# Altium schematic sheet SH2 - 5V VICOR DCDC.SchDoc
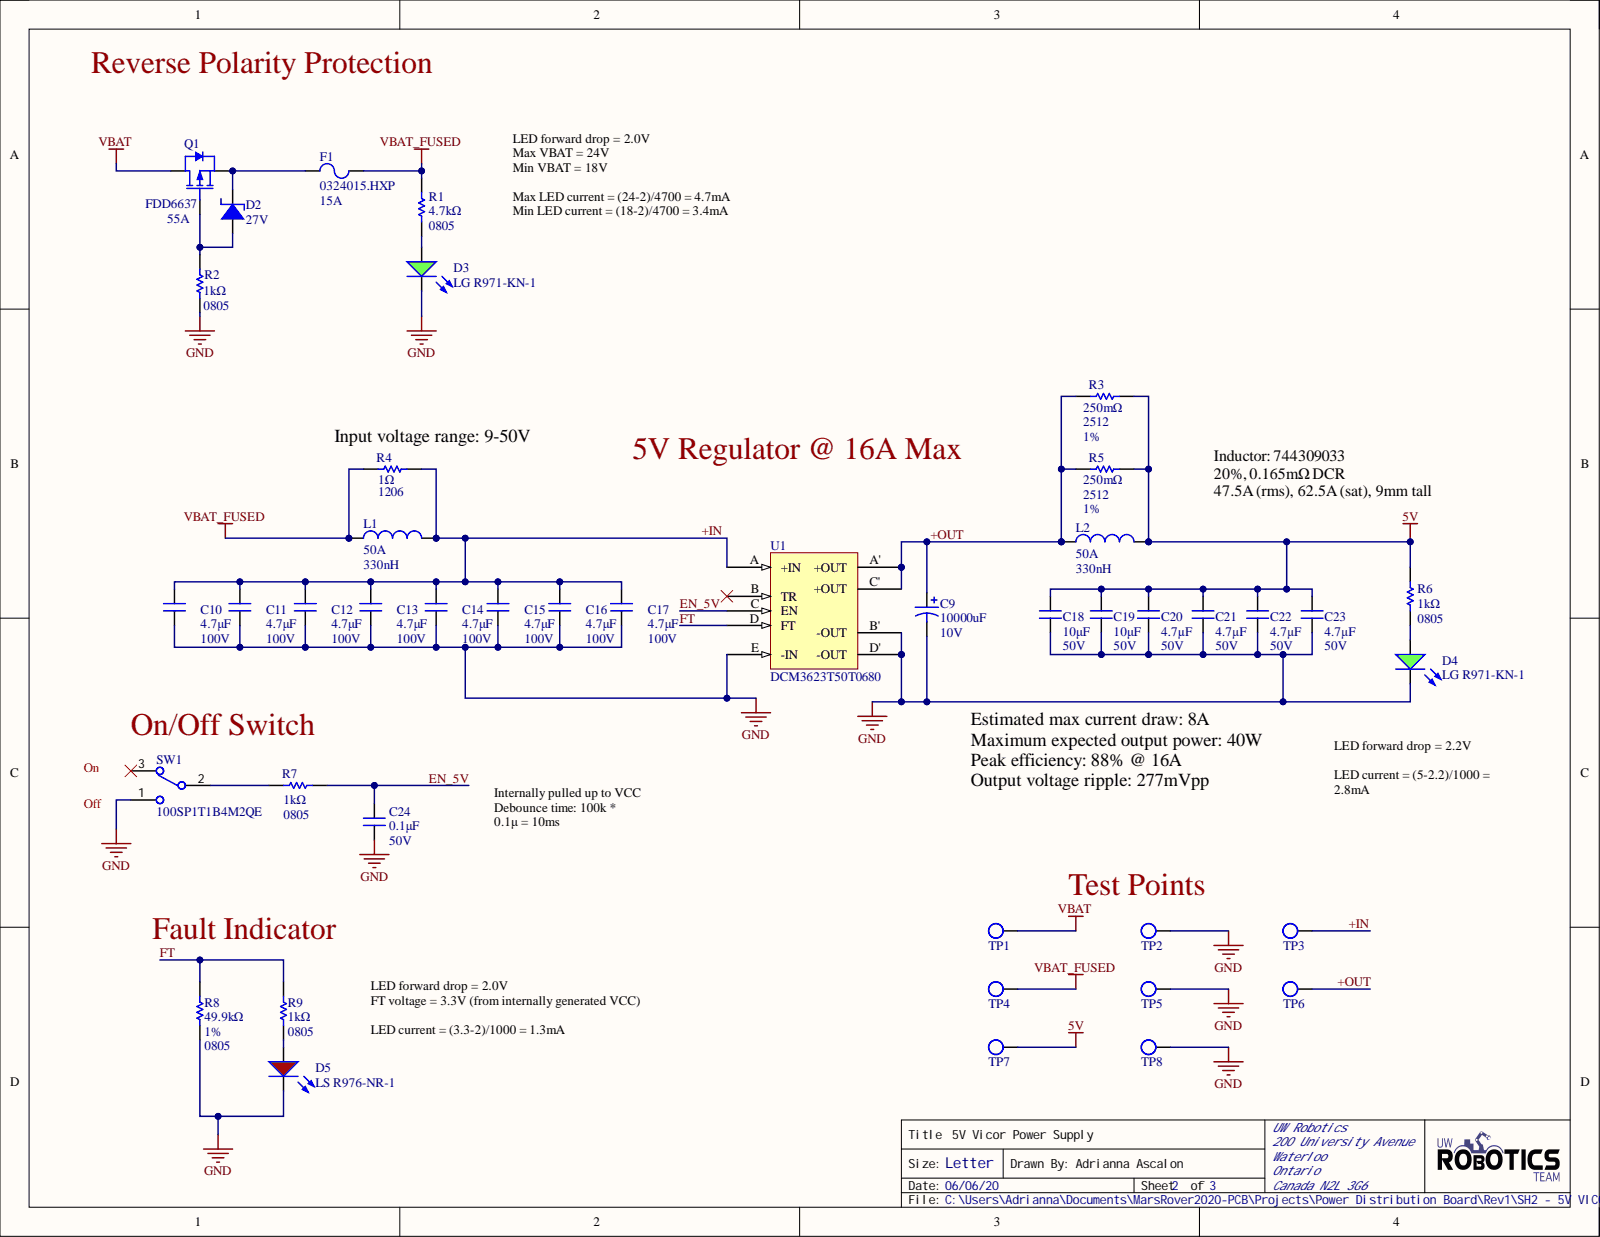
[diagram p0: SH2 - 5V VICOR DCDC.SchDoc]

## Parts
C9  Capacitance: 10000uF  Voltage Rating: 10V  [CAP_UBY_18X25_NC]  (hidden comment: URS1A103MHD1TN)
C10  4.7μF  Voltage: 100V  [CAPC5750X7R2A]
C11  4.7μF  Voltage: 100V  [CAPC5750X7R2A]
C12  4.7μF  Voltage: 100V  [CAPC5750X7R2A]
C13  4.7μF  Voltage: 100V  [CAPC5750X7R2A]
C14  4.7μF  Voltage: 100V  [CAPC5750X7R2A]
C15  4.7μF  Voltage: 100V  [CAPC5750X7R2A]
C16  4.7μF  Voltage: 100V  [CAPC5750X7R2A]
C17  4.7μF  Voltage: 100V  [CAPC5750X7R2A]
C18  value 10μF  Voltage Rating: 50V  [CAPC1206(3216)]  (hidden comment: 10uF)
C19  value 10μF  Voltage Rating: 50V  [CAPC1206(3216)]  (hidden comment: 10uF)
C20  4.7µF  Voltage: 50V  [CAPC1206(3216)]
C21  4.7µF  Voltage: 50V  [CAPC1206(3216)]
C22  4.7µF  Voltage: 50V  [CAPC1206(3216)]
C23  4.7µF  Voltage: 50V  [CAPC1206(3216)]
C24  0.1μF  Voltage Rating: 50V  [CAPC2012X140N]
D2  Working Voltage: 27V  [SOT23-3]  (hidden comment: DIO_ZENER_27V)
D3  LG R971-KN-1  [LED_0805_LINE]
D4  LG R971-KN-1  [LED_0805_LINE]
D5  LS R976-NR-1  [LED_0805_LINE]
F1  0324015.HXP  Current Rating: 15A  [FUSE]
L1  744309033  Inductance: 330nH  Max DC Current: 50A  [WE-HCM 1390]
L2  744309033  Inductance: 330nH  Max DC Current: 50A  [WE-HCM 1390]
Q1  FDD6637  Continuous Drain Current (ID): 55A  [D-PAK]
R1  value 4.7kΩ  Case Code (Imperial): 0805  [RESC2012X60N]  (hidden comment: RES_4.7kΩ_0805)
R2  1kΩ  Case Code (Imperial): 0805  [RESC2012X60N]
R3  Case Code (Imperial): 2512  Resistance: 250mΩ  [RESC6432X89X76NL25T25]  (hidden comment: WSL2512R2500FEA)
R4  Case Code (Imperial): 1206  Resistance: 1Ω  [RESC1206(3216)_N]  (hidden comment: RES_1Ω_1206)
R5  Case Code (Imperial): 2512  Resistance: 250mΩ  Resistance Tolerance: 1%  [RESC6432X89X76NL25T25]  (hidden comment: WSL2512R2500FEA)
R6  1kΩ  Case Code (Imperial): 0805  [RESC2012X60N]
R7  1kΩ  Case Code (Imperial): 0805  [RESC2012X60N]
R8  49.9kΩ  Case Code (Imperial): 0805  Tolerance: 1%  [RESC2012X60N]
R9  1kΩ  Case Code (Imperial): 0805  [RESC2012X60N]
SW1  100SP1T1B4M2QE  [100SP1T1B4M2QE]
TP1  TESTPOINT_LOOP  [TESTPOINT_LOOP]  (value not drawn)
TP2  TESTPOINT_LOOP  [TESTPOINT_LOOP]  (value not drawn)
TP3  TESTPOINT_LOOP  [TESTPOINT_LOOP]  (value not drawn)
TP4  TESTPOINT_LOOP  [TESTPOINT_LOOP]  (value not drawn)
TP5  TESTPOINT_LOOP  [TESTPOINT_LOOP]  (value not drawn)
TP6  TESTPOINT_LOOP  [TESTPOINT_LOOP]  (value not drawn)
TP7  TESTPOINT_LOOP  [TESTPOINT_LOOP]  (value not drawn)
TP8  TESTPOINT_LOOP  [TESTPOINT_LOOP]  (value not drawn)
U1  DCM3623T50T0680  [DCM3623T50T0680]

## Nets
5V: C18.2 C19.2 C20.2 C21.2 C22.2 C23.2 L2.2 R3.2 R5.2 R6.2 TP7.1
GND: C9.2 C10.1 C11.1 C12.1 C13.1 C14.1 C15.1 C16.1 C17.1 C18.1 C19.1 C20.1 C21.1 C22.1 C23.1 C24.1 D3.2 D4.2 D5.2 R2.1 R8.2 SW1.1 TP2.1 TP5.1 TP8.1 U1.B'(-OUT) U1.D'(-OUT) U1.E(-IN)
VBAT: Q1.2 TP1.1
VBAT_FUSED: F1.2 L1.1 R1.2 R4.1 TP4.1
+IN: C10.2 C11.2 C12.2 C13.2 C14.2 C15.2 C16.2 C17.2 L1.2 R4.2 TP3.1 U1.A(+IN)
+OUT: C9.1 L2.1 R3.1 R5.1 TP6.1 U1.A'(+OUT) U1.C'(+OUT)
EN_5V: C24.2 R7.2 U1.C(EN)
FT: R8.1 R9.2 U1.D(FT)
NetD2_1: D2.1 Q1.1 R2.2
NetD2_2: D2.2 F1.1 Q1.3
NetD3_1: D3.1 R1.1
NetD4_1: D4.1 R6.1
NetD5_1: D5.1 R9.1
NetR7_1: R7.1 SW1.2

Not connected: SW1 3; U1 B(TR)

## Library data of the parts
C9 = URS1A103MHD1TN: CAP ALUM 10000UF 20% 10V RADIAL; Manufacturer 1: Nichicon; Life (Hours): 2000Hour; Ripple Current: 2.35A; Case/Package: Radial; Component Kind: Standard; Height - Seated (Max): 1.043inch; Lead Pitch: 0.295inch; Manufacturer Part Number 1: URS1A103MHD1TN; Max Operating Temperature: 85°C; Min Operating Temperature: -40°C; Mount: Through Hole; Supplier 1: Digi-Key; Supplier Part Number 1: 493-12364-1-ND; Tolerance: 20%; Library Name: UWRT_Schematic_Library_2020.SchLib
C10-C17 = CAP_4.7uF_100V_2220: CAP CER 4.7UF 100V X7R 2220; Manufacturer: TDK; Manufacturer Part Number: C5750X7R2A475M230KA; Tolerance: 20%; Capacitor Value: 4.7μF; Case Code (Imperial): 2220; Case Code (Metric): 5750; Category: Ceramic Capacitors; Component Kind: Standard; Composition: Ceramic; Depth: 5mm; Dielectric: X7R; Height: 2.3mm; Length: 5.7mm; Max Operating Temperature: 125°C; Min Operating Temperature: -55°C; Mount: Surface Mount; Number of Pins: 2; Package: 2220 (5750 Metric); REACH SVHC: No SVHC; Supplier 1: Digi-Key; Supplier Part Number 1: 445-1450-1-ND; Termination: SMD/SMT; Thickness: 0.098inch; Value: 4.7μF; Voltage Rating: 100V; Voltage Rating (DC): 100V; Weight: 0.016932oz; Width: 0.197inch; Library Name: UWRT_Schematic_Library_2020.SchLib
C18 C19 = CAP_10uF_50V_1206: CAP CER 10UF 50V X5R 1206; Manufacturer 1: Murata; Manufacturer Part Number 1: GRT31CR61H106KE01L; Case Code (Imperial): 1206; Case Code (Metric): 3216; Component Kind: Standard; Dielectric: X5R; Dimensions: 0.126" L x 0.063" W (3.20mm x 1.6mm); Manufacturer Part Numer 1: GRT31CR61H106KE01L; Mount: Surface Mount; Package: 1206 (3216 Metric); Signal Integrity: Cap; Simulation: CAP; Supplier 1: Digi-Key; Supplier Part Number 1: 490-12456-1-ND; Library Name: UWRT_Schematic_Library_2020.SchLib
C20-C23 = CAP_4.7µF_50V_1206: CAP CER 4.7UF 50V X7R 1206; Manufacturer 1: Murata; Manufacturer Part Number 1: GRM31CR71H475KA12L; Material: Ceramic; Tolerance: 10%; Capacitance: 4.7uF; Case Code (Imperial): 1206; Case Code (Metric): 3216; Component Kind: Standard; ComponentLink7Description: https://datasheet.ciiva.com/6270/partnumbering-e-01-6270217.pdf?src-supplier=Digi-Key; ComponentLink7URL: https://datasheet.ciiva.com/6270/partnumbering-e-01-6270217.pdf?src-supplier=Digi-Key; ComponentLink8Description: https://datasheet.ciiva.com/6270/partnumbering-e-01-6270218.pdf?src-supplier=Digi-Key; ComponentLink8URL: https://datasheet.ciiva.com/6270/partnumbering-e-01-6270218.pdf?src-supplier=Digi-Key; Depth: 1.6mm; Dielectric: X7R; Height: 1.6mm; Length: 3.2mm; Max Operating Temperature: 125°C; Min Operating Temperature: -55°C; Mount: Surface Mount; Package: 1206 (3216 Metric); REACH SVHC: No SVHC; Supplier 1: Digi-Key; Supplier Part Number 1: 490-6521-1-ND; Termination: SMD/SMT; Thickness: 0.071inch; Voltage Rating: 50V; Voltage Rating (DC): 50V; Width: 0.063inch; Library Name: UWRT_Schematic_Library_2020.SchLib
C24 = CAP_0.1uF_50V_0805: CAP CER 0.1UF 50V X7R 0805; Manufacturer 1: Murata Electronics; Manufacturer Part Number 1: GCD21BR71H104KA01L; Tolerance: 10%; Case Code (Imperial): 0805; Case Code (Metric): 2012; Component Kind: Standard; Depth: 1.25mm; Dielectric: X7R; Height: 1.25mm; Length: 2mm; Max Operating Temperature: 125°C; Min Operating Temperature: -55°C; Mount: Surface Mount; Package: 0805 (2012 Metric); Ratings: AEC-Q200; Supplier 1: Digi-Key; Supplier Part Number 1: 490-11955-1-ND; Termination: SMD/SMT; Thickness: 0.049inch; Value: 0.1μF; Width: 0.049inch; Library Name: UWRT_Schematic_Library_2020.SchLib
D2 = DIO_ZENER_27V: DIODE ZENER 27V 250MW SOT23; Manufacturer 1: Nexperia USA Inc.; Manufacturer Part Number 1: BZX84-C27,215; Forward Voltage: 0.9V; Tolerance: 5%; Component Kind: Standard; Mount: Surface Mount; Package/Case: SOT-23-3; Supplier 1: Digi-Key; Supplier Part Number 1: 1727-5026-2-ND; Library Name: UWRT_Schematic_Library_2020.SchLib
D3 D4 = DIO_LED_G: LED GREEN DIFFUSED 0805 SMD; Manufacturer 1: OSRAM; Forward Voltage Drop: 2.2V; Component Kind: Standard; Manufacturer Part Number 1: LG R971-KN-1; Mount: Surface Mount; Package: 0805 (2012 Metric); Simulation: LED1; Supplier 1: Digi-Key; Supplier Part Number 1: 475-1410-1-ND; Library Name: UWRT_Schematic_Library_2020.SchLib
D5 = DIO_LED_R: LED RED DIFFUSED 0805 SMD; Manufacturer 1: OSRAM; Forward Voltage Drop: 2V; Component Kind: Standard; Manufacturer Part Number 1: LS R976-NR-1; Mount: Surface Mount; Package: 0805 (2012 Metric); Simulation: LED1; Supplier 1: Digi-Key; Supplier Part Number 1: 475-1278-1-ND; Library Name: UWRT_Schematic_Library_2020.SchLib
F1 = 0324015.HXP: FUSE CERM 15A 250VAC 125VDC 3AB; Manufacturer 1: Littelfuse; Approvals: UL,CSA,CE,K-Mark; DC Cold Resistance: 5.05mR; Fuse Type: Cartridge, Ceramic; Voltage Rating (AC): 250V; Voltage Rating (DC): 125V; Case/Package: Axial; Component Kind: Standard; Diameter: 6.985mm; Fuse Current: 15A; Housing Material: Ceramic; Length: 1.288inch; Manufacturer Part Number 1: 0324015.HXP; Max Operating Temperature: 125°C; Min Operating Temperature: -55°C; Mount: Through Hole; REACH SVHC: Unknown; Resistance: 5.05mR; Supplier 1: Digi-Key; Supplier Part Number 1: F1870-ND; Termination: Axial; Working Voltage: 250V; Library Name: UWRT_Schematic_Library_2020.SchLib
L1 L2 = 744309033: IND 330NH 47.5A 0.165 MOHM; Manufacturer 1: Wurth Electronics; Core Material: Zinc; DC Resistance (DCR): 0.165mR; Inductance Tolerance: 20%; Saturation Current: 62.5A; Self Resonant Frequency: 158MHz; Shielding: Shielded; Test Frequency: 100kHz; Case/Package: Nonstandard; Component Kind: Standard; Composition: Wirewound; Current Rating: 47.5A; Height: 9mm; Height - Seated (Max): 0.374inch; Length: 0.551inch; Manufacturer Part Number 1: 744309033; Max Operating Temperature: 125°C; Min Operating Temperature: -40°C; Mount: Surface Mount; REACH SVHC: Unknown; RMS Current (Irms): 50A; Supplier 1: Newark; Termination: SMD/SMT; Tolerance: 20%; Width: 0.512inch; Library Name: UWRT_Schematic_Library_2020.SchLib
Q1 = FDD6637: MOSFET P-CH 35V 13A DPAK; Manufacturer 1: ON Semiconductor / Fairchild; Drain to Source Breakdown Voltage: -35V; Drain to Source Resistance: 11.6mR; Element Configuration: Single; Fall Time: 36ns; Gate to Source Voltage (Vgs): 25V; Input Capacitance: 2.37nF; Max Power Dissipation: 57W; Nominal Vgs: -1.6V; Number of Elements: 1; Power Dissipation: 3.1W; Rise Time: 10ns; Turn-Off Delay Time: 62ns; Turn-On Delay Time: 18ns; Case/Package: TO-252; Component Kind: Standard; ComponentLink7Description: https://datasheet.ciiva.com/28548/686553-28548172.pdf?src-supplier=Element14; ComponentLink7URL: https://datasheet.ciiva.com/28548/686553-28548172.pdf?src-supplier=Element14; ComponentLink8Description: https://datasheet.ciiva.com/6382/fdd6637-6382456.pdf?src-supplier=Digi-Key; ComponentLink8URL: https://datasheet.ciiva.com/6382/fdd6637-6382456.pdf?src-supplier=Digi-Key; ComponentLink9Description: https://datasheet.ciiva.com/6382/fdd6637-6382457.pdf?src-supplier=Digi-Key; ComponentLink9URL: https://datasheet.ciiva.com/6382/fdd6637-6382457.pdf?src-supplier=Digi-Key; Drain to Source Voltage (Vdss): 35V; Height: 2.39mm; Length: 6.73mm; Manufacturer Part Number 1: FDD6637; Max Operating Temperature: 150°C; Min Operating Temperature: -55°C; Mount: Surface Mount; Number of Pins: 3; On-State Resistance: 11.6MR; Rds On Max: 11.6mR; REACH SVHC: No SVHC; Supplier 1: Digi-Key; Supplier Part Number 1: FDD6637CT-ND; Threshold Voltage: -1.6V; Weight: 0.26037g; Width: 6.22mm; Library Name: UWRT_Schematic_Library_2020.SchLib
R1 = RES_4.7kΩ_0805: RES SMD 4.7K OHM 5% 1/8W 0805; Manufacturer 1: Yageo; Manufacturer Part Number 1: RC0805JR-074K7L; Power Rating: 125mW; Resistance: 4.7kR; Temperature Coefficient: 100ppm/°C; Voltage Rating: 150V; Case Code (Metric): 2012; Component Kind: Standard; Composition: Thick Film; Contact Plating: Tin,Lead,Matte; Depth: 1.25mm; Length: 2mm; Max Operating Temperature: 155°C; Military Standard: Not; Min Operating Temperature: -55°C; Mount: Surface Mount; Package: 0805 (2012 Metric); REACH SVHC: Unknown; Supplier 1: Digi-Key; Supplier Part Number 1: 311-4.7KARCT-ND; Termination: SMD/SMT; Tolerance: 5%; Library Name: UWRT_Schematic_Library_2020.SchLib
R2 R6 R9 = RES_1kΩ_0805: RES SMD 1K OHM 1% 1/8W 0805; Manufacturer 1: Yageo; Manufacturer Part Number 1: RC0805FR-071KL; Max Power Dissipation: 125mW; Temperature Coefficient: 25ppm/°C; Voltage Rating: 150V; Case Code (Metric): 2012; Case/Package: 0805; Component Kind: Standard; Composition: Thin Film; Contact Plating: Tin; Depth: 1.25mm; Features: Moisture Resistant,Automotive AEC-Q200,Anti-Sulfur; Height: 0.022inch; Length: 2mm; Max Operating Temperature: 155°C; Military Standard: Not; Min Operating Temperature: -55°C; Mount: Surface Mount; Number of Pins: 2; Number of Terminations: 2; Package: 0805 (2012 Metric); Power Rating: 125mW; REACH SVHC: Unknown; Resistance: 1K; Supplier 1: Digi-Key; Supplier Part Number 1: 311-1.00KCRCT-ND; Termination: SMD/SMT; Tolerance: 1%; Value: 1kΩ; Voltage Rating (DC): 150V; Weight: 0.000194oz; Width: 0.049inch; Library Name: UWRT_Schematic_Library_2020.SchLib
R3 R5 = RES_250mΩ_2512: RES 0.25 OHM 1% 1W 2512; Manufacturer: Vishay; Automotive Qualification Standard: AEC-Q200; Product Range: WSLSeries; Resistor Case Style: 2512[6432Metric]; Resistor Element Type: MetalStrip; SVHC: NoSVHC(15-Jun-2015); Temperature Coefficient: ±75ppm/°C; Case Code (Metric): 6432; Component Kind: Standard; Datasheet URL: http://www.vishay.com/docs/30100/wsl.pdf; Datasheet Version: 07/2016; Manufacturer URL: http://www.vishay.com/; Mounting Technology: SurfaceMount; MSL: MSL1-Unlimited; Package: 2512 (6432 Metric); Package Description: 2-Pin Surface Mount Device, Body 6.35 x 3.18 mm; Package Reference: 2512; Power Rating: 1W; Library Name: UWRT_Schematic_Library_2020.SchLib
  R3: Resistance Tolerance: 1%
R4 = RES_1Ω_1206: RES 1 OHM 5% 1/4W 1206; Manufacturer: KOA Speer; Manufacturer Part Number: RK73B2BTTE1R0J; Power Rating: 250mW; Temperature Coefficient: 200ppm/°C; Voltage Rating: 200V; Case Code (Metric): 3216; Category: Chip SMD Resistors; Component Kind: Standard; Composition: Thick Film; Height: 0.6mm; Length: 3.2mm; Max Operating Temperature: 155°C; Min Operating Temperature: -55°C; Package: 1206 (3216 Metric); Supplier 1: Digi-Key; Supplier Part Number 1: 2019-RK73B2BTTE1R0JCT-ND; Termination: SMD/SMT; Tolerance: 5%; Width: 1.6mm; Library Name: UWRT_Schematic_Library_2020.SchLib
R7 = RES_100kΩ_0805: RES SMD 100K OHM 0.5% 1/8W 0805; Manufacturer 1: Panasonic; Manufacturer Part Number 1: ERA-6AED104V; Power Rating: 125mW; Resistance: 100kΩ; Temperature Coefficient: 25ppm/°C; Voltage Rating: 200V; Case Code (Metric): 2012; Category: Chip SMD Resistors; Component Kind: Standard; Composition: Thin Film; Contact Plating: Tin; Depth: 1.25mm; Height: 0.5mm; Length: 2mm; Max Operating Temperature: 155°C; Military Standard: Not; Min Operating Temperature: -55°C; Mount: Surface Mount; Package: 0805 (2012 Metric); Supplier 1: Digi-Key; Supplier Part Number 1: P123795CT-ND; Termination: SMD/SMT; Tolerance: 0.5%; Width: 1.25mm; Library Name: UWRT_Schematic_Library_2020.SchLib
R8 = RES_49.9kΩ_0805: RES SMD 49.9K OHM 1% 1/8W 0805; Manufacturer 1: Vishay Dale; Manufacturer Part Number 1: TNPW080549K9BEEN; Max Power Dissipation: 125mW; Resistance: 49.9kR; Temperature Coefficient: 25ppm/°C; Voltage Rating: 150V; Case Code (Metric): 2012; Component Kind: Standard; Composition: Thin Film; Contact Plating: Tin; Depth: 1.25mm; Features: Moisture Resistant,Automotive AEC-Q200,Anti-Sulfur; Height: 0.022inch; Length: 2mm; Max Operating Temperature: 125°C; Military Standard: Not; Min Operating Temperature: -55°C; Mount: Surface Mount; Number of Pins: 2; Number of Terminations: 2; Package: 0805 (2012 Metric); Power Rating: 125mW; REACH SVHC: Unknown; Supplier 1: Digi-Key; Supplier Part Number 1: 541-3802-1-ND; Termination: SMD/SMT; Voltage Rating (DC): 150V; Weight: 0.000194oz; Width: 0.049inch; Library Name: UWRT_Schematic_Library_2020.SchLib
SW1 = SW_SPDT: SWITCH TOGGLE SPDT 5A 120V; Manufacturer 1: E-Switch; Actuator Length: 12.19mm; Actuator Material: Brass,Chrome; Actuator Type: Standard Round; Electrical Life: 40000Cycles; Illumination Type: Non-Illuminated,Illuminated; Switch Function: On-On; Voltage Rating (DC): 28V; Component Kind: Standard; Contact Material: Silver; Contact Plating: Silver; Current Rating: 5A; Manufacturer Part Number 1: 100SP1T1B4M2QE; Max Operating Temperature: 85°C; Min Operating Temperature: -30°C; Mount: Through Hole; Orientation: Vertical; Supplier 1: Digi-Key; Supplier Part Number 1: EG2355-ND; Termination: PC Pin; Throw Configuration: SPDT; Voltage Rating (AC): 120V; Library Name: UWRT_Schematic_Library_2020.SchLib
TP1-TP8 = TESTPOINT_LOOP: PC TEST POINT MINIATURE WHITE; Manufacturer 1: Keystone Electronics; Manufacturer Part Number 1: 5002; Component Kind: Standard; Mount: Through Hole; Supplier 1: Digi-Key; Supplier Part Number 1: 36-5002-ND; Library Name: UWRT_Schematic_Library_2020.SchLib
U1 = DCM3623T50T0680: DC DC CONVERTER 5V 80W; Component Kind: Standard; Library Name: UWRT_Schematic_Library_2020.SchLib
